# Altium schematic sheet Mostki H.SchDoc
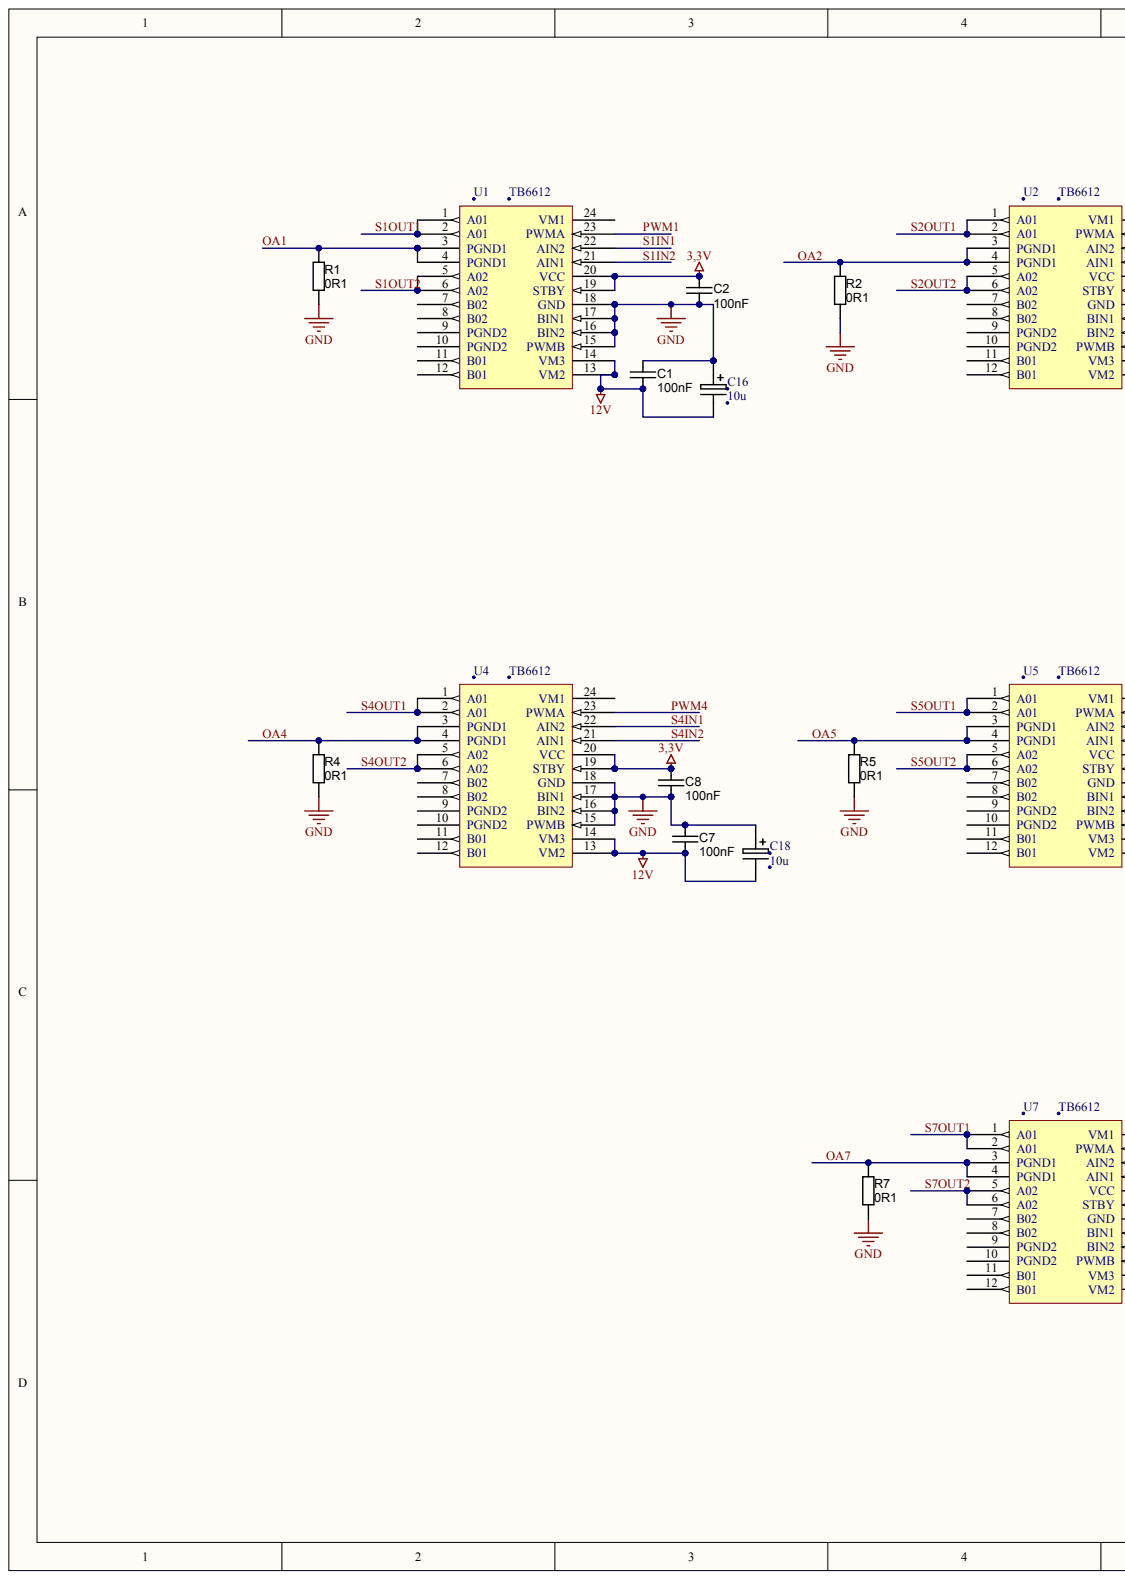
[diagram p0: Mostki H.SchDoc, left]
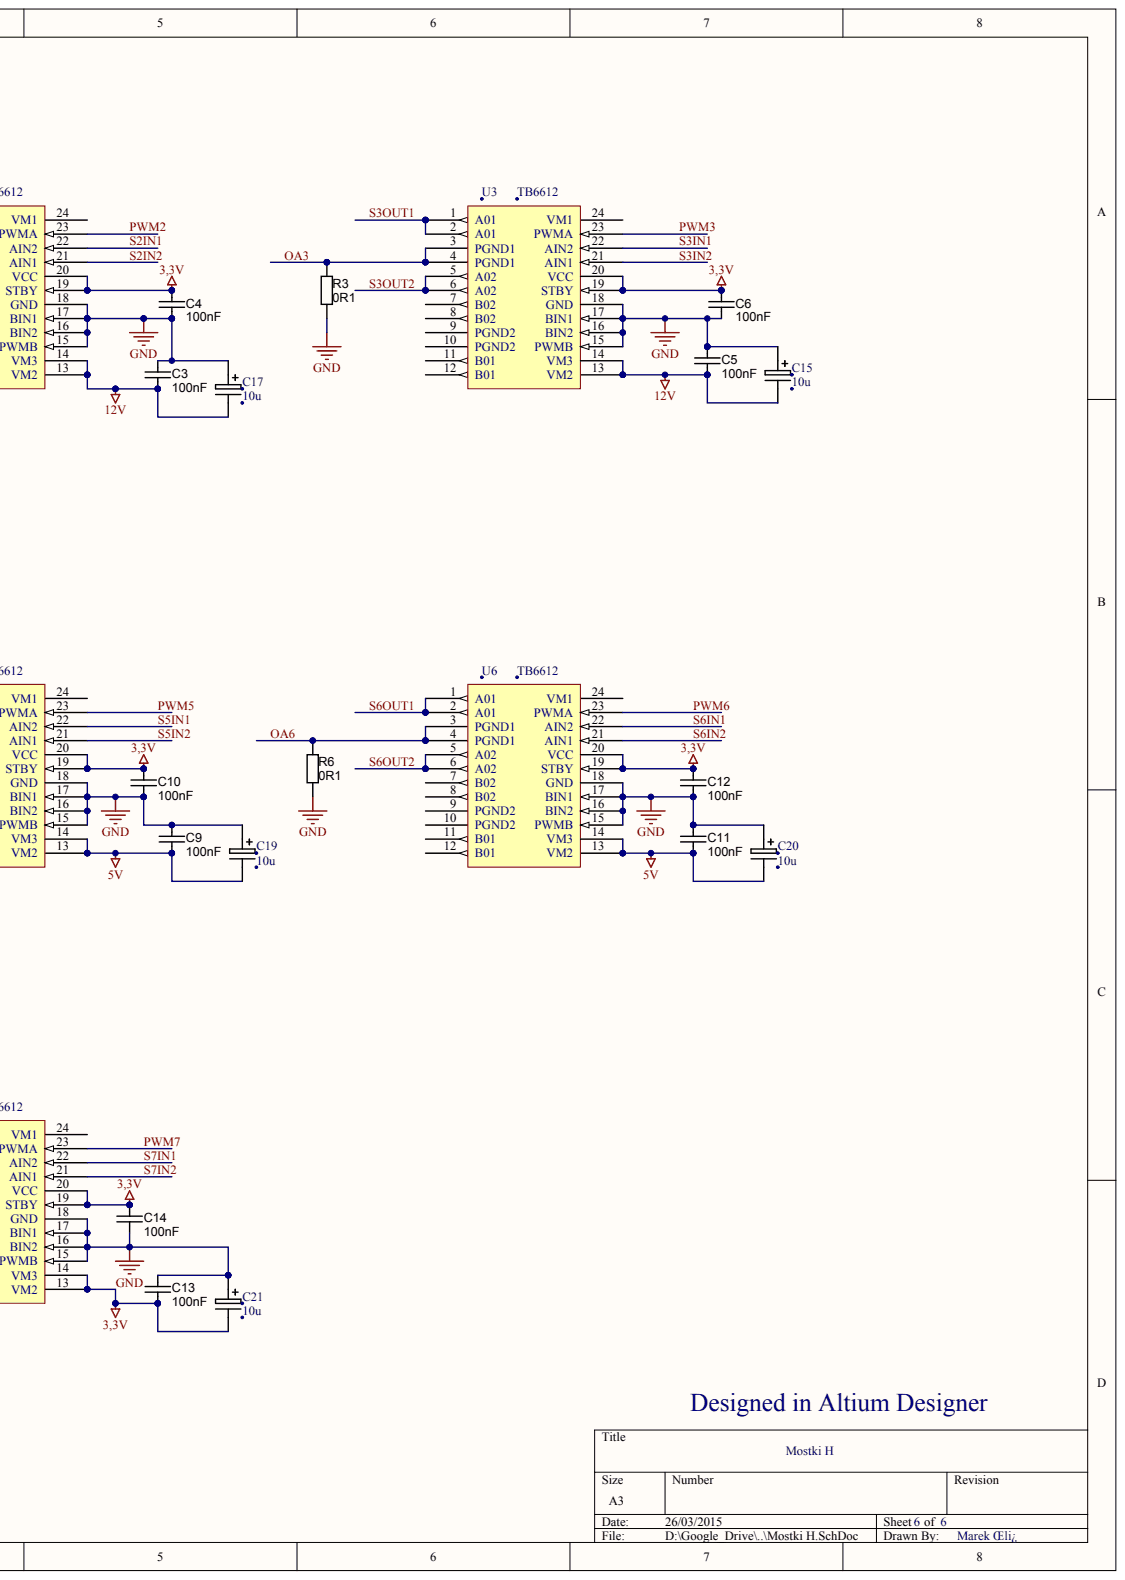
[diagram p1: Mostki H.SchDoc, right]

## Parts
C1  value 100nF  (hidden comment: approved sub OK)
C2  value 100nF  (hidden comment: approved sub OK)
C3  value 100nF  (hidden comment: approved sub OK)
C4  value 100nF  (hidden comment: approved sub OK)
C5  value 100nF  (hidden comment: approved sub OK)
C6  value 100nF  (hidden comment: approved sub OK)
C7  value 100nF  (hidden comment: approved sub OK)
C8  value 100nF  (hidden comment: approved sub OK)
C9  value 100nF  (hidden comment: approved sub OK)
C10  value 100nF  (hidden comment: approved sub OK)
C11  value 100nF  (hidden comment: approved sub OK)
C12  value 100nF  (hidden comment: approved sub OK)
C13  value 100nF  (hidden comment: approved sub OK)
C14  value 100nF  (hidden comment: approved sub OK)
C15  10u
C16  10u
C17  10u
C18  10u
C19  10u
C20  10u
C21  10u
R1  value 0R1  (hidden comment: Metal Film)
R2  value 0R1  (hidden comment: Metal Film)
R3  value 0R1  (hidden comment: Metal Film)
R4  value 0R1  (hidden comment: Metal Film)
R5  value 0R1  (hidden comment: Metal Film)
R6  value 0R1  (hidden comment: Metal Film)
R7  value 0R1  (hidden comment: Metal Film)
U1  TB6612
U2  TB6612
U3  TB6612
U4  TB6612
U5  TB6612
U6  TB6612
U7  TB6612

## Nets
3,3V: C2.1 C4.1 C6.1 C8.1 C10.1 C12.1 C13.2 C14.1 C21.2 U1.19(STBY) U1.20(VCC) U2.19(STBY) U2.20(VCC) U3.19(STBY) U3.20(VCC) U4.19(STBY) U4.20(VCC) U5.19(STBY) U5.20(VCC) U6.19(STBY) U6.20(VCC) U7.13(VM2) U7.14(VM3) U7.19(STBY) U7.20(VCC)
5V: C9.2 C11.2 C19.2 C20.2 U5.13(VM2) U5.14(VM3) U6.13(VM2) U6.14(VM3)
12V: C1.2 C3.2 C5.2 C7.2 C15.2 C16.2 C17.2 C18.2 U1.13(VM2) U1.14(VM3) U2.13(VM2) U2.14(VM3) U3.13(VM2) U3.14(VM3) U4.13(VM2) U4.14(VM3)
GND: C1.1 C2.2 C3.1 C4.2 C5.1 C6.2 C7.1 C8.2 C9.1 C10.2 C11.1 C12.2 C13.1 C14.2 C15.1 C16.1 C17.1 C18.1 C19.1 C20.1 C21.1 R1.2 R2.2 R3.2 R4.2 R5.2 R6.2 R7.2 U1.15(PWMB) U1.16(BIN2) U1.17(BIN1) U1.18(GND) U2.15(PWMB) U2.16(BIN2) U2.17(BIN1) U2.18(GND) U3.15(PWMB) U3.16(BIN2) U3.17(BIN1) U3.18(GND) U4.15(PWMB) U4.16(BIN2) U4.17(BIN1) U4.18(GND) U5.15(PWMB) U5.16(BIN2) U5.17(BIN1) U5.18(GND) U6.15(PWMB) U6.16(BIN2) U6.17(BIN1) U6.18(GND) U7.15(PWMB) U7.16(BIN2) U7.17(BIN1) U7.18(GND)
OA1: R1.1 U1.3(PGND1) U1.4(PGND1)
OA2: R2.1 U2.3(PGND1) U2.4(PGND1)
OA3: R3.1 U3.3(PGND1) U3.4(PGND1)
OA4: R4.1 U4.3(PGND1) U4.4(PGND1)
OA5: R5.1 U5.3(PGND1) U5.4(PGND1)
OA6: R6.1 U6.3(PGND1) U6.4(PGND1)
OA7: R7.1 U7.3(PGND1) U7.4(PGND1)
PWM1: U1.23(PWMA)
PWM2: U2.23(PWMA)
PWM3: U3.23(PWMA)
PWM4: U4.23(PWMA)
PWM5: U5.23(PWMA)
PWM6: U6.23(PWMA)
PWM7: U7.23(PWMA)
S1IN1: U1.22(AIN2)
S1IN2: U1.21(AIN1)
S1OUT1: U1.1(A01) U1.2(A01)
S1OUT2: U1.5(A02) U1.6(A02)
S2IN1: U2.22(AIN2)
S2IN2: U2.21(AIN1)
S2OUT1: U2.1(A01) U2.2(A01)
S2OUT2: U2.5(A02) U2.6(A02)
S3IN1: U3.22(AIN2)
S3IN2: U3.21(AIN1)
S3OUT1: U3.1(A01) U3.2(A01)
S3OUT2: U3.5(A02) U3.6(A02)
S4IN1: U4.22(AIN2)
S4IN2: U4.21(AIN1)
S4OUT1: U4.1(A01) U4.2(A01)
S4OUT2: U4.5(A02) U4.6(A02)
S5IN1: U5.22(AIN2)
S5IN2: U5.21(AIN1)
S5OUT1: U5.1(A01) U5.2(A01)
S5OUT2: U5.5(A02) U5.6(A02)
S6IN1: U6.22(AIN2)
S6IN2: U6.21(AIN1)
S6OUT1: U6.1(A01) U6.2(A01)
S6OUT2: U6.5(A02) U6.6(A02)
S7IN1: U7.22(AIN2)
S7IN2: U7.21(AIN1)
S7OUT1: U7.1(A01) U7.2(A01)
S7OUT2: U7.5(A02) U7.6(A02)

Not connected: U1 7(B02) 8(B02) 9(PGND2) 10(PGND2) 11(B01) 12(B01) 24(VM1); U2 7(B02) 8(B02) 9(PGND2) 10(PGND2) 11(B01) 12(B01) 24(VM1); U3 7(B02) 8(B02) 9(PGND2) 10(PGND2) 11(B01) 12(B01) 24(VM1); U4 7(B02) 8(B02) 9(PGND2) 10(PGND2) 11(B01) 12(B01) 24(VM1); U5 7(B02) 8(B02) 9(PGND2) 10(PGND2) 11(B01) 12(B01) 24(VM1); U6 7(B02) 8(B02) 9(PGND2) 10(PGND2) 11(B01) 12(B01) 24(VM1); U7 7(B02) 8(B02) 9(PGND2) 10(PGND2) 11(B01) 12(B01) 24(VM1)

## Library data of the parts
C1-C14 = (no symbol name): Manufacturer 1: TDK Corporation; Manufacturer Part Number 1: C2012X7R1C105K/1.25; Tolerance: ±10%; Ratings: 16V; X7R; Material: ceramic; Package / Case: 0805 (2012 metric); Supplier 1: Digi-Key; Supplier Part Number 1: 445-1358-1-ND
R1-R7 = (no symbol name): Manufacturer 1: Vishay/BC Components; Manufacturer Part Number 1: PR01000101009JR500; Tolerance: ±5%; Ratings: 1W; Package / Case: 2.5x8.0mm DxL; Supplier 1: Digi-Key; Supplier Part Number 1: PPC10W-1CT-ND
C15-C21 U1-U7 = (no symbol name)
  C15-C21: Value: 10u
